# Altium schematic sheet bolid-rs485-usb-sd.SchDoc
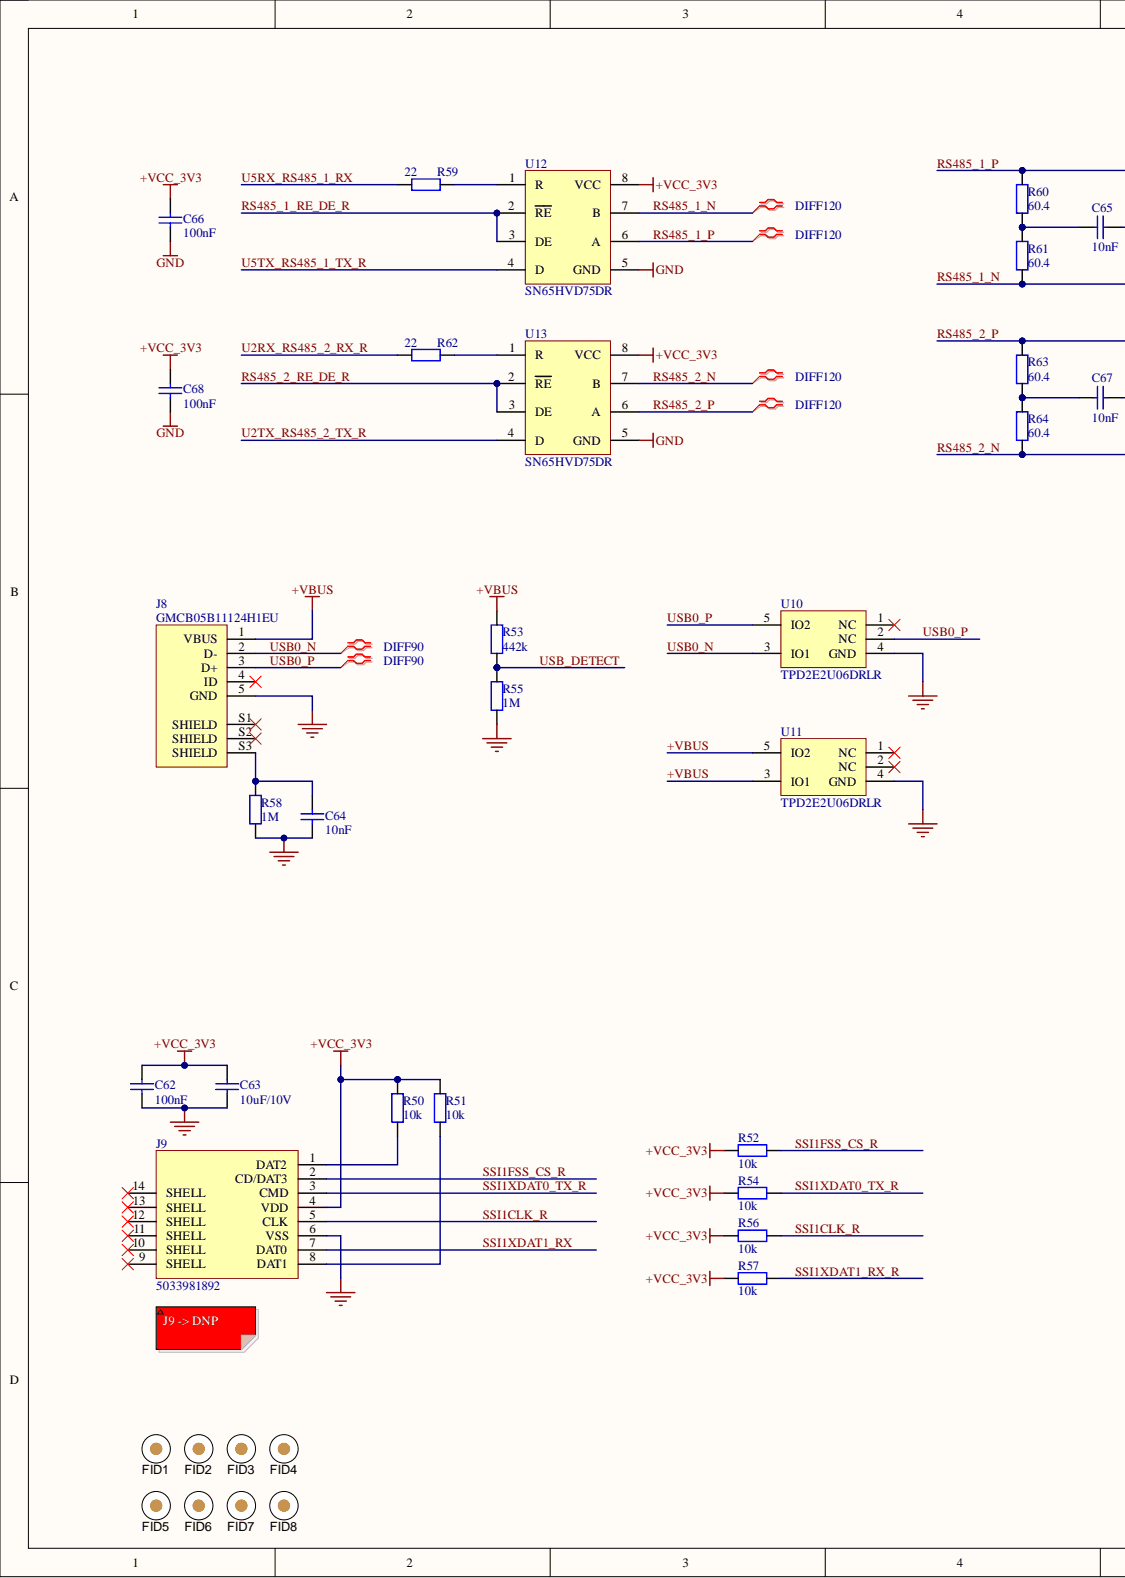
[diagram p0: bolid-rs485-usb-sd.SchDoc, left]
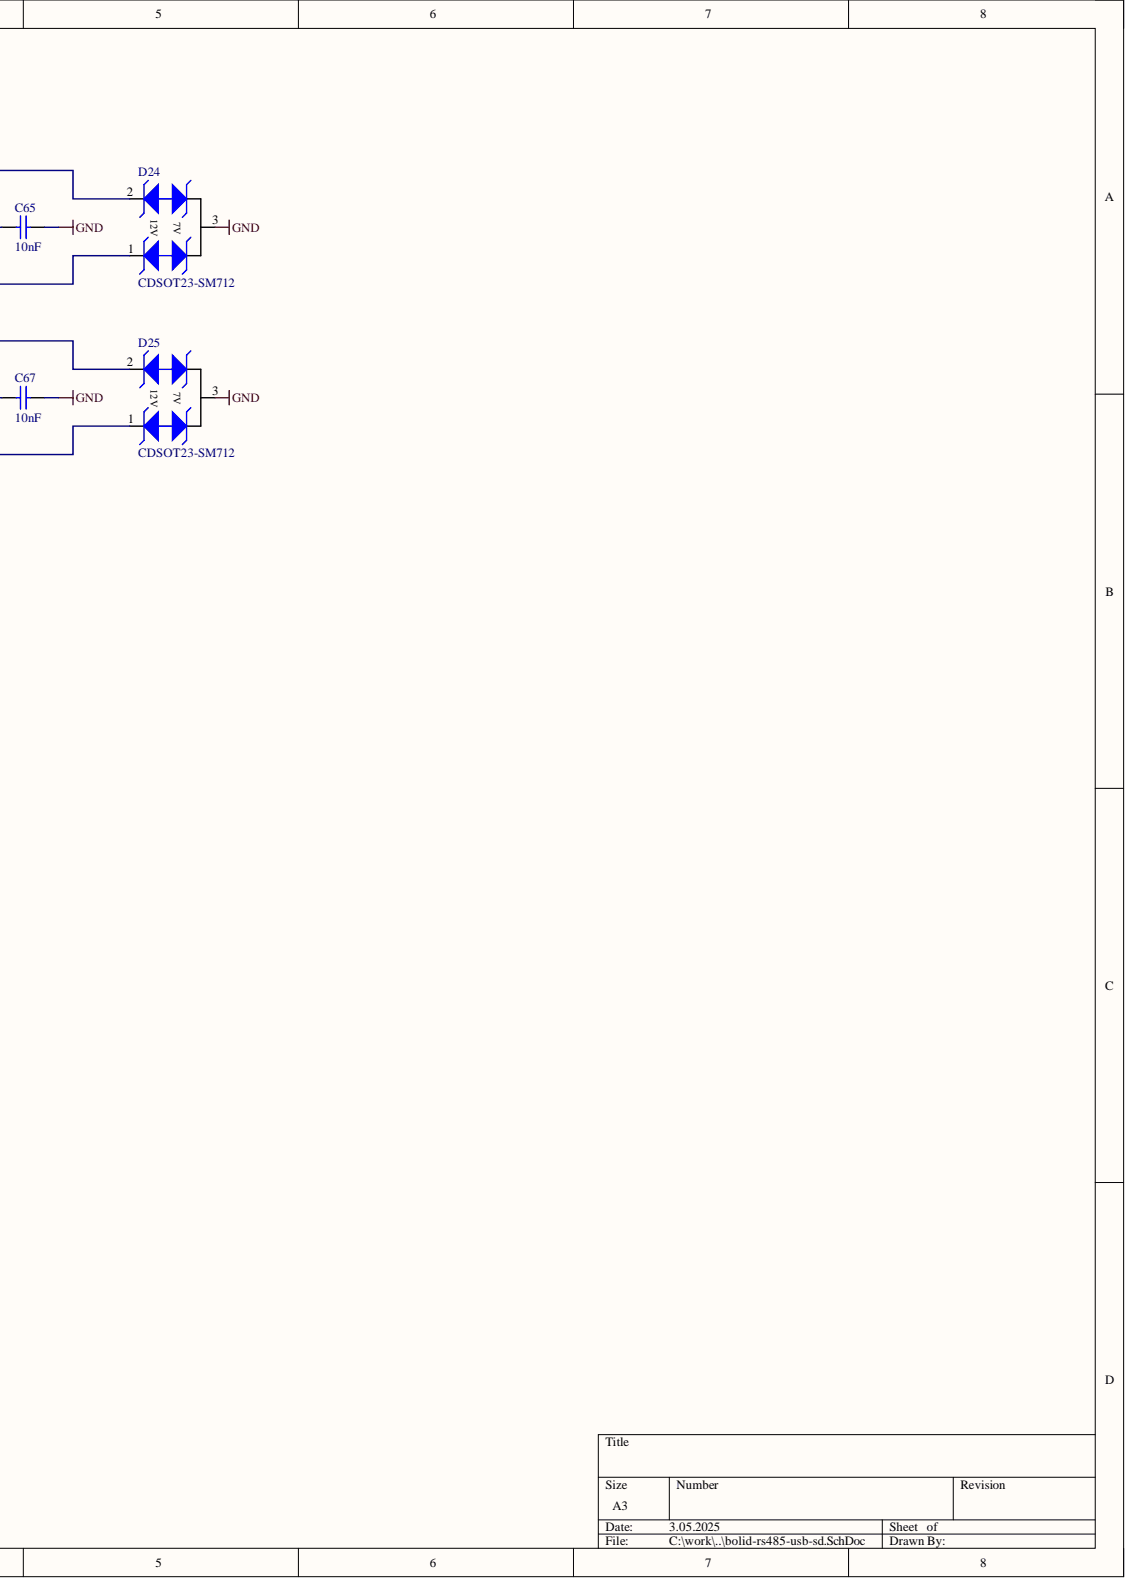
[diagram p1: bolid-rs485-usb-sd.SchDoc, right]

## Parts
C62  value 100nF  [C0402-CAPC100X50X55L22 - Tayio Yuden TDK]  (hidden comment: GRM155R71H104KE14D)
C63  Value Displayed: 10uF/10V  [C0805-CAPC200X125X135L50N - Taiyo YudenN]  (hidden comment: GRM21BR71A106KA73K)
C64  Value Displayed: 10nF  [C0402-CAPC100X50X55L22 - Tayio Yuden TDK]  (hidden comment: GCM155R71H103KA55D)
C65  Value Displayed: 10nF  [C0402-CAPC100X50X55L22 - Tayio Yuden TDK]  (hidden comment: GCM155R71H103KA55D)
C66  value 100nF  [C0402-CAPC100X50X55L22 - Tayio Yuden TDK]  (hidden comment: GRM155R71H104KE14D)
C67  Value Displayed: 10nF  [C0402-CAPC100X50X55L22 - Tayio Yuden TDK]  (hidden comment: GCM155R71H103KA55D)
C68  value 100nF  [C0402-CAPC100X50X55L22 - Tayio Yuden TDK]  (hidden comment: GRM155R71H104KE14D)
D24  CDSOT23-SM712  Reverse Standoff Voltage: 12V  [Bourns SOT-23-3L SOT23-3P95_229X117L49X40N]
D25  CDSOT23-SM712  Reverse Standoff Voltage: 12V  [Bourns SOT-23-3L SOT23-3P95_229X117L49X40N]
FID1  Fiducial  [Fiducial10-20]  (value not drawn)  (no BOM)
FID2  Fiducial  [Fiducial10-20]  (value not drawn)  (no BOM)
FID3  Fiducial  [Fiducial10-20]  (value not drawn)  (no BOM)
FID4  Fiducial  [Fiducial10-20]  (value not drawn)  (no BOM)
FID5  Fiducial  [Fiducial10-20]  (value not drawn)  (no BOM)
FID6  Fiducial  [Fiducial10-20]  (value not drawn)  (no BOM)
FID7  Fiducial  [Fiducial10-20]  (value not drawn)  (no BOM)
FID8  Fiducial  [Fiducial10-20]  (value not drawn)  (no BOM)
J8  GMCB05B11124H1EU  [AMPHENOL-GMCB05B11124H1EU]
J9  5033981892  [MOLEX MICROSD 5033981892]
R50  value 10k  [R0402-RESC100X50X40L22N]  (hidden comment: RC0402FR-0710KL)
R51  value 10k  [R0402-RESC100X50X40L22N]  (hidden comment: RC0402FR-0710KL)
R52  value 10k  [R0402-RESC100X50X40L22N]  (hidden comment: RC0402FR-0710KL)
R53  value 442k  [R0402-RESC100X50X40L22N]  (hidden comment: ERJ-2RKF4423X)
R54  value 10k  [R0402-RESC100X50X40L22N]  (hidden comment: RC0402FR-0710KL)
R55  value 1M  [R0402-RESC100X50X40L22N]  (hidden comment: AC0402FR-071ML)
R56  value 10k  [R0402-RESC100X50X40L22N]  (hidden comment: RC0402FR-0710KL)
R57  value 10k  [R0402-RESC100X50X40L22N]  (hidden comment: RC0402FR-0710KL)
R58  value 1M  [R0402-RESC100X50X40L22N]  (hidden comment: AC0402FR-071ML)
R59  value 22  [R0402-RESC100X50X40L22N]  (hidden comment: RC0402FR-1322RL)
R60  value 60.4  [R0603-RESC160X80X55L30N]  (hidden comment: RC0603FR-0760R4L)
R61  value 60.4  [R0603-RESC160X80X55L30N]  (hidden comment: RC0603FR-0760R4L)
R62  value 22  [R0402-RESC100X50X40L22N]  (hidden comment: RC0402FR-1322RL)
R63  value 60.4  [R0603-RESC160X80X55L30N]  (hidden comment: RC0603FR-0760R4L)
R64  value 60.4  [R0603-RESC160X80X55L30N]  (hidden comment: RC0603FR-0760R4L)
U10  TPD2E2U06DRLR  [TI SOT-5X3 DRL0005A SOTFL50P160X60-5N]
U11  TPD2E2U06DRLR  [TI SOT-5X3 DRL0005A SOTFL50P160X60-5N]
U12  SN65HVD75DR  Case/Package: D  [TI SOIC8 D0008A SOIC8P127_490X599X175L84X41N]
U13  SN65HVD75DR  Case/Package: D  [TI SOIC8 D0008A SOIC8P127_490X599X175L84X41N]

## Nets
+VCC_3V3: C62.2 C63.1 C66.1 C68.1 J9.4(VDD) R50.1 R51.1 R52.1 R54.1 R56.1 R57.1 U12.8(VCC) U13.8(VCC)
GND: C62.1 C63.2 C64.1 C65.2 C66.2 C67.2 C68.2 D24.3 D25.3 J8.5(GND) J9.6(VSS) R55.1 R58.1 U10.4(GND) U11.4(GND) U12.5(GND) U13.5(GND)
+VBUS: J8.1(VBUS) R53.2 U11.3(IO1) U11.5(IO2)
RS485_1_N: D24.1 R61.1 U12.7(B)
RS485_1_P: D24.2 R60.2 U12.6(A)
RS485_1_RE_DE_R: U12.2(RE) U12.3(DE)
RS485_2_N: D25.1 R64.1 U13.7(B)
RS485_2_P: D25.2 R63.2 U13.6(A)
RS485_2_RE_DE_R: U13.2(RE) U13.3(DE)
SSI1CLK_R: J9.5(CLK) R56.2
SSI1FSS_CS_R: J9.2(CD/DAT3) R52.2
SSI1XDAT0_TX_R: J9.3(CMD) R54.2
SSI1XDAT1_RX: J9.7(DAT0)
SSI1XDAT1_RX_R: R57.2
U2RX_RS485_2_RX_R: R62.1
U2TX_RS485_2_TX_R: U13.4(D)
U5RX_RS485_1_RX: R59.1
U5TX_RS485_1_TX_R: U12.4(D)
USB0_N: J8.2(D-) U10.3(IO1)
USB0_P: J8.3(D+) U10.2(NC) U10.5(IO2)
USB_DETECT: R53.1 R55.2
NetC64_2: C64.2 J8.S3(SHIELD) R58.2
NetC65_1: C65.1 R60.1 R61.2
NetC67_1: C67.1 R63.1 R64.2
NetJ9_1: J9.1(DAT2) R50.2
NetJ9_8: J9.8(DAT1) R51.2
NetR59_2: R59.2 U12.1(R)
NetR62_2: R62.2 U13.1(R)

Not connected: FID1 X; FID2 X; FID3 X; FID4 X; FID5 X; FID6 X; FID7 X; FID8 X; J8 4(ID) S1(SHIELD) S2(SHIELD); J9 9(SHELL) 10(SHELL) 11(SHELL) 12(SHELL) 13(SHELL) 14(SHELL); U10 1(NC); U11 1(NC) 2(NC)

## Library data of the parts
C62 C66 C68 = GRM155R71H104KE14D: MLCC 0402 (1005) 100nF 10% 50V X7R; Brand: Murata Electronics; Capacitance: 0.1 uF; Capacitance - pF: 100000 pF; Class: Class 2; Type: Chip Multilayer Ceramic Capacitor for General Purpose; Voltage Rating DC: 50 VDC; Capacitance - nF: 100 nF; Case Code - in: 0402; Case Code - mm: 1005; Category: Multilayer Ceramic Capacitors MLCC - SMD/SMT; Component Kind: Standard; ComponentLink1Description: https://www.mouser.com/datasheet/2/281/murata_03052018_GRM_Series_1-1310166.pdf; ComponentLink1URL: https://www.mouser.com/datasheet/2/281/murata_03052018_GRM_Series_1-1310166.pdf; Dielectric: X7R; Height: 0.5 mm; Length: 1 mm; Library Name: capacitors2.IntLib; Manufacturer: Murata Electronics; Manufacturer Part Number: GRM155R71H104KE14D; Maximum Operating Temperature: + 125 C; Minimum Operating Temperature: - 55 C; Minimum Order Quantity: 1; Package / Case: 0402 (1005 metric); Packaging: Cut Tape; Pricing 1: 1=0.090, 10=0.038, 100=0.016, 500=0.012, 1000=0.009, 2500=0.008, 5000=0.007, 10000=0.005 (EUR); Product: General Type MLCCs; Product Type: Ceramic Capacitors; Reeling: True; RoHS: RoHS Compliant; RoHS - Mouser: Y; Series: GRM; Signal Integrity: Cap Semi; Simulation: CAP; Standard Pack Qty: 10000; Stock 1: 3511637; Subcategory: Capacitors; Supplier 1: Mouser; Supplier Part Number 1: 81-GRM155R71H104KE4D; Termination: Standard; Termination Style: SMD/SMT; Tolerance: 10 %; Value Displayed: 100nF; Width: 0.5 mm
C63 = GRM21BR71A106KA73K: MLCC 0805 (2012) 10uF 10% 10V X7R; Brand: Murata Electronics; Class: Class 2; Type: Chip Multilayer Ceramic Capacitor for General Purpose; Value: 10u; Voltage Rating DC: 10 VDC; Capacitance: 10 uF; Case Code - in: 0805; Case Code - mm: 2012; Category: Multilayer Ceramic Capacitors MLCC - SMD/SMT; Component Kind: Standard; ComponentLink1Description: https://www.mouser.com/datasheet/2/281/murata_03052018_GRM_Series_1-1310166.pdf; ComponentLink1URL: https://www.mouser.com/datasheet/2/281/murata_03052018_GRM_Series_1-1310166.pdf; Dielectric: X7R; Height: 1.25 mm; Length: 2 mm; Library Name: capacitors2.IntLib; Manufacturer: Murata Electronics; Manufacturer Part Number: GRM21BR71A106KA73K; Maximum Operating Temperature: + 125 C; Minimum Operating Temperature: - 55 C; Minimum Order Quantity: 1; Package / Case: 0805 (2012 metric); Packaging: Cut Tape; Pricing 1: 1=0.207, 10=0.144, 100=0.072, 500=0.050, 1000=0.043, 2500=0.040, 5000=0.036, 10000=0.030, 20000=0.028 (EUR); Product: General Type MLCCs; Product Type: Ceramic Capacitors; Reeling: True; RoHS: RoHS Compliant; RoHS - Mouser: Y; Series: GRM; Signal Integrity: Cap Semi; Simulation: CAP; Standard Pack Qty: 10000; Stock 1: 108729; Subcategory: Capacitors; Supplier 1: Mouser; Supplier Part Number 1: 81-GRM21BR71A106KA3K; Termination: Standard; Termination Style: SMD/SMT; Tolerance: 10 %; Width: 1.25 mm
C64 C65 C67 = GCM155R71H103KA55D: MLCC 0402 (1005) 10nF 10% 50V X7R; Brand: Murata Electronics; Capacitance: 0.01 uF; Capacitance - pF: 10000 pF; Class: Class 2; Qualification: AEC-Q200; Type: Chip Multilayer Ceramic Capacitors for Automotive; Value: 10n; Voltage Rating DC: 50 VDC; Capacitance - nF: 10 nF; Case Code - in: 0402; Case Code - mm: 1005; Category: Multilayer Ceramic Capacitors MLCC - SMD/SMT; Component Kind: Standard; ComponentLink1Description: https://www.mouser.com/datasheet/2/281/murata_03122018_GCM_Series-1310150.pdf; ComponentLink1URL: https://www.mouser.com/datasheet/2/281/murata_03122018_GCM_Series-1310150.pdf; Dielectric: X7R; Height: 0.5 mm; Length: 1 mm; Library Name: capacitors2.IntLib; Manufacturer: Murata Electronics; Manufacturer Part Number: GCM155R71H103KA55D; Maximum Operating Temperature: + 125 C; Minimum Operating Temperature: - 55 C; Minimum Order Quantity: 1; Package / Case: 0402 (1005 metric); Packaging: Cut Tape; Pricing 1: 1=0.090, 10=0.033, 100=0.015, 500=0.011, 1000=0.009, 2500=0.008, 5000=0.006, 10000=0.005, 20000=0.004 (EUR); Product: Automotive MLCCs; Product Type: Ceramic Capacitors; Reeling: True; RoHS: RoHS Compliant; RoHS - Mouser: Y; Series: GCM; Signal Integrity: Cap Semi; Simulation: CAP; Standard Pack Qty: 10000; Stock 1: 7174893; Subcategory: Capacitors; Supplier 1: Mouser; Supplier Part Number 1: 81-GCM155R71H103KA5D; Termination: Standard; Termination Style: SMD/SMT; Tolerance: 10 %; Width: 0.5 mm
D24 D25 = CDSOT23-SM712: TVS DIODE 7VWM/12VWM SOT23; Manufacturer: Bourns; Breakdown Voltage: 13.3V; Capacitance: 75pF; Clamping Voltage: 19V; Diode Type: ZENER; Direction: Bidirectional; Element Configuration: Dual; ESD Protection: Yes; Leakage Current: 20uA; Max Power Dissipation: 600W; Max Surge Current: 30A; Number of Bidirectional Channels: 2; Peak Pulse Current: 17A; Peak Pulse Power: 400W; Polarity: Bidirectional,Unidirectional; Power Line Protection: No; Test Current: 1mA; Case/Package: SOT-23; Category: TVS Diodes; Component Kind: Standard; ComponentLink1Description: https://datasheet.ciiva.com/1281/1670933-1281331.pdf?src-supplier=Farnell; ComponentLink1URL: https://datasheet.ciiva.com/1281/1670933-1281331.pdf?src-supplier=Farnell; ComponentLink2Description: https://datasheet.ciiva.com/4510/cdsot23-sm712-25932-4510261.pdf?src-supplier=Mouser; ComponentLink2URL: https://datasheet.ciiva.com/4510/cdsot23-sm712-25932-4510261.pdf?src-supplier=Mouser; ComponentLink3Description: https://datasheet.ciiva.com/11193/cdsot23-sm712-11193846.pdf?src-supplier=Avnet; ComponentLink3URL: https://datasheet.ciiva.com/11193/cdsot23-sm712-11193846.pdf?src-supplier=Avnet; ComponentLink4Description: https://datasheet.ciiva.com/22696/1670933-22696779.pdf?src-supplier=Element14; ComponentLink4URL: https://datasheet.ciiva.com/22696/1670933-22696779.pdf?src-supplier=Element14; ComponentLink5Description: https://datasheet.ciiva.com/26828/getdatasheetpartid-418501-26828522.pdf?src-supplier=Verical; ComponentLink5URL: https://datasheet.ciiva.com/26828/getdatasheetpartid-418501-26828522.pdf?src-supplier=Verical; ComponentLink6Description: https://datasheet.ciiva.com/337/cdsot23-sm712-337451.pdf?src-supplier=Digi-Key; ComponentLink6URL: https://datasheet.ciiva.com/337/cdsot23-sm712-337451.pdf?src-supplier=Digi-Key; ComponentLink7Description: https://datasheet.ciiva.com/337/cdsot23-sm712-337452.pdf?src-supplier=Digi-Key; ComponentLink7URL: https://datasheet.ciiva.com/337/cdsot23-sm712-337452.pdf?src-supplier=Digi-Key; Contact Plating: Tin; Depth: 1.4mm; Height: 1.11mm; Length: 3.04mm; Library Name: semiconductors2.IntLib; Manufacturer Part Number: CDSOT23-SM712; Max Breakdown Voltage: 13.3V; Max Operating Temperature: 150°C; Max Reverse Leakage Current: 20uA; Min Breakdown Voltage: 13.3V; Min Operating Temperature: -55°C; Mount: Surface Mount; Number of Channels: 2; Number of Elements: 2; Number of Pins: 3; Package Quantity: 1; Packaging: Tape and Reel; Power Dissipation: 400W; Pricing 1: 1=1,63000, 10=1,44000, 50=1,44000, 100=1,14000, 250=1,11000, 500=0,94100, 1000=0,74300, 3000=0,69300, 6000=0,67500, 9000=0,62900, 10000=0,62900 (USD); Radiation Hardening: No; REACH SVHC: No SVHC; Resistor Type: Zener; Reverse Breakdown Voltage: 13.3V; RoHS: Yes; RoHS Compliant: Yes; Series: -; Stock 1: 1112; Supplier 1: Mouser; Supplier Part Number 1: 652-CDSOT23-SM712; Termination: SMD/SMT; Width: 0.055inch; Working Voltage: 12V
FID1-FID8 = Fiducial: Fiducial mark on PCB; Library Name: hardware2.IntLib
J8 = GMCB05B11124H1EU: Micro USB 2.0 Type B Receptacle Vertical SMT Shell Dip Length 1.7mm, Tape and Reel Packagin; Manufacturer: Amphenol; Category: Connectors; Component Kind: Standard; Library Name: connectors2.IntLib; Manufacturer Part Number: GMCB05B11124H1EU; Pricing 1: 1=1,01, 10=0,773, 50=0,641, 100=0,592, 1000=0,483, 10000=0,47 (USD); RoHS: No; Stock 1: 17853; Supplier 1: Mouser; Supplier Part Number 1: 523-GMCB05B11124H1EU
J9 = 5033981892: 1.10mm Pitch microSD Memory Card Connector, Normal Mount Surface Mount, Push-Push Type, 1.28mm Height; Manufacturer: Molex; Current Rating: 500mA; Gender: Male; Memory Type: 4498,11064MemoryType; Number of Circuits: 8; Pitch: 1.1mm; Plating: 154ConductorMaterial; Voltage Rating: 10V; Category: Memory Connectors; Component Kind: Standard; ComponentLink1Description: https://datasheet.ciiva.com/23941/1740947-23941665.pdf?src-supplier=Newark; ComponentLink1URL: https://datasheet.ciiva.com/23941/1740947-23941665.pdf?src-supplier=Newark; ComponentLink2Description: https://datasheet.ciiva.com/22744/1740946-22744573.pdf?src-supplier=Element14; ComponentLink2URL: https://datasheet.ciiva.com/22744/1740946-22744573.pdf?src-supplier=Element14; Contact Material: Bronze,Stainless Steel; Contact Plating: Gold; Depth: 14.05mm; Halogen Free: Low Halogen; Housing Material: Thermoplastic; Length: 13.6mm; Library Name: connectors2.IntLib; Manufacturer Part Number: 5033981892; Max Operating Temperature: 85°C; Min Operating Temperature: -25°C; Mount: Surface Mount; Number of Contacts: 8; Number of Rows: 1; Orientation: Right Angle; Package Quantity: 2400; Packaging: Tape and Reel; Pricing 1: 1=2,97, 10=1,94, 50=1,94, 100=1,68, 1000=1,5, 10000=1,35 (USD); Reach SVHC Compliant: Unknown; RoHS: Yes; RoHS Compliant: Yes; Series: 503398; Stock 1: 16315; Supplier 1: Mouser; Supplier Part Number 1: 538-503398-1892; Termination: Solder; Voltage Rating (AC): 10V; Width: 14.05mm
R50-R52 R54 R56 R57 = RC0402FR-0710KL: RES 0402 (1005) 10.0k 1% 0.0625W 50V Thick Film; Brand: Yageo; Power Rating: 62.5 mW, 125 mW; Resistance: 10 kOhms; Temperature Coefficient: 100 PPM / C; Application: High Reliability; Case Code - in: 0402; Case Code - mm: 1005; Category: Thick Film Resistors - SMD; Component Kind: Standard; ComponentLink1Description: https://www.mouser.com/datasheet/2/447/PYu-RC_Group_51_RoHS_L_10-1664068.pdf; ComponentLink1URL: https://www.mouser.com/datasheet/2/447/PYu-RC_Group_51_RoHS_L_10-1664068.pdf; Features: -; Height: 0.35 mm; Length: 1 mm; Library Name: resistors2.IntLib; Manufacturer: Yageo; Manufacturer Part Number: RC0402FR-0710KL; Maximum Operating Temperature: + 155 C; Minimum Operating Temperature: - 55 C; Minimum Order Quantity: 1; Mounting Style: PCB Mount; Package / Case: -; Packaging: Cut Tape; Pricing 1: 1=0.090, 10=0.011, 100=0.004, 1000=0.003, 10000=0.003 (EUR); Product: Thick Film Resistors SMD; Product Type: Thick Film Resistors; Reeling: True; RoHS: RoHS Compliant; RoHS - Mouser: Y; Series: RC; Signal Integrity: Res; Simulation: RESISTOR; Standard Pack Qty: 10000; Stock 1: 20942447; Subcategory: Resistors; Supplier 1: Mouser; Supplier Part Number 1: 603-RC0402FR-0710KL; Technology: Thick Film; Termination Style: SMD/SMT; Tolerance: 1 %; Type: General Purpose; Voltage Rating: 50 V; Width: 0.5 mm
R53 = ERJ-2RKF4423X: RES 0402 (1005) 442.0k 1% 0.100W 50V Thick Film; Brand: Panasonic; Power Rating: 100 mW (1/10 W); Qualification: AEC-Q200; Resistance: 442 kOhms; Temperature Coefficient: 100 PPM / C; Application: Automotive Grade; Case Code - in: 0402; Case Code - mm: 1005; Category: Thick Film Resistors - SMD; Component Kind: Standard; ComponentLink1Description: https://www.mouser.com/datasheet/2/315/AOA0000C304-1149620.pdf; ComponentLink1URL: https://www.mouser.com/datasheet/2/315/AOA0000C304-1149620.pdf; Features: Precision Resistors; Height: 0.35 mm; Length: 1 mm; Library Name: resistors2.IntLib; Manufacturer: Panasonic; Manufacturer Part Number: ERJ-2RKF4423X; Maximum Operating Temperature: + 155 C; Minimum Operating Temperature: - 55 C; Minimum Order Quantity: 1; Mounting Style: PCB Mount; Packaging: Cut Tape; Pricing 1: 1=0.085, 10=0.025, 100=0.010, 1000=0.005, 2500=0.004, 5000=0.003, 10000=0.003 (EUR); Product: Thick Film Resistors SMD; Product Type: Thick Film Resistors; Reeling: True; RoHS: RoHS Compliant By Exemption; RoHS - Mouser: E; Series: ERJ-2Rx; Signal Integrity: Res; Simulation: RESISTOR; Standard Pack Qty: 10000; Stock 1: 56067; Subcategory: Resistors; Supplier 1: Mouser; Supplier Part Number 1: 667-ERJ-2RKF4423X; Technology: Thick Film; Termination Style: SMD/SMT; Tolerance: 1 %; Voltage Rating: 50 V; Width: 0.5 mm
R55 R58 = AC0402FR-071ML: RES 0402 (1005) 1.00M 1% 0.0625W 50V Thick Film; Brand: YAGEO; Power Rating: 62.5 mW (1/16 W); Qualification: AEC-Q200; Resistance: 1 MOhms; Temperature Coefficient: 100 PPM / C; Application: Automotive Grade; Case Code - in: 0402; Case Code - mm: 1005; Category: Thick Film Resistors - SMD; Component Kind: Standard; ComponentLink1Description: https://www.mouser.com/datasheet/2/447/Yageo_PYu_AC_51_RoHS_L_7_1714230-1874691.pdf; ComponentLink1URL: https://www.mouser.com/datasheet/2/447/Yageo_PYu_AC_51_RoHS_L_7_1714230-1874691.pdf; Height: 0.32 mm; Length: 1 mm; Library Name: resistors2.IntLib; Manufacturer: YAGEO; Manufacturer Part Number: AC0402FR-071ML; Maximum Operating Temperature: + 155 C; Minimum Operating Temperature: - 55 C; Minimum Order Quantity: 1; Mounting Style: PCB Mount; Packaging: Cut Tape; Pricing 1: 1=0.085, 10=0.019, 100=0.008, 1000=0.004, 2500=0.003, 10000=0.003 (EUR); Product: Thick Film Resistors General Purpose; Product Type: Thick Film Resistors; Reeling: True; RoHS: RoHS Compliant By Exemption; RoHS - Mouser: E; Series: AC; Signal Integrity: Res; Simulation: RESISTOR; Standard Pack Qty: 10000; Stock 1: 142366; Subcategory: Resistors; Supplier 1: Mouser; Supplier Part Number 1: 603-AC0402FR-071ML; Technology: Thick Film; Termination Style: SMD/SMT; Tolerance: 1 %; Type: General Purpose Resistor; Voltage Rating: 50 V; Width: 0.5 mm
R59 R62 = RC0402FR-1322RL: RES 0402 (1005) 22.0 ohm 1% 0.0625W 50V Thick Film; Manufacturer: Yageo; Power Rating: 62.5mW; Resistance: 22R; Temperature Coefficient: 100ppm/°C; Case Code (Imperial): 0402; Case Code (Metric): 1005; Case/Package: 0402; Category: Chip SMD Resistors; Component Kind: Standard; ComponentLink1Description: https://datasheet.ciiva.com/17423/pyu-rc0402-51-rohs-l-6-r-17423721.pdf?src-supplier=Future+Electronics; ComponentLink1URL: https://datasheet.ciiva.com/17423/pyu-rc0402-51-rohs-l-6-r-17423721.pdf?src-supplier=Future+Electronics; ComponentLink2Description: https://datasheet.ciiva.com/8890/pyu-rc0402-51-rohs-l-6-8890187.pdf?src-supplier=Avnet; ComponentLink2URL: https://datasheet.ciiva.com/8890/pyu-rc0402-51-rohs-l-6-8890187.pdf?src-supplier=Avnet; Contact Plating: Tin,Matte; Height: 0.35mm; Lead Free: Lead Free; Length: 1mm; Library Name: resistors2.IntLib; Manufacturer Part Number: RC0402FR-1322RL; Max Operating Temperature: 155°C; Min Operating Temperature: -55°C; Packaging: Tape and Reel; Pricing 1: 1=0,10000, 10=0,01200, 50=0,01200, 100=0,00500, 1000=0,00300, 10000=0,00300 (USD); Radiation Hardening: No; Resistor Type: Thick Film; RoHS: Yes; RoHS Compliant: Yes; Series: RC; Signal Integrity: Res; Simulation: RESISTOR; Size Code: 0402; Stock 1: 130563; Supplier 1: Mouser; Supplier Part Number 1: 603-RC0402FR-1322RL; Termination: SMD/SMT; Tolerance: 1%; Voltage Rating: 50V; Weight: 2.3E-05oz; Width: 0.5mm
R60 R61 R63 R64 = RC0603FR-0760R4L: RES 0603 (1608) 60.4 ohm 1% 0.100W 75V Thick Film; Brand: Yageo; Power Rating: 100 mW (1/10 W); Resistance: 60.4 Ohms; Temperature Coefficient: 100 PPM / C; Application: High Reliability; Case Code - in: 0603; Case Code - mm: 1608; Category: Thick Film Resistors - SMD; Component Kind: Standard; ComponentLink1Description: https://www.mouser.com/datasheet/2/447/PYu-RC_Group_51_RoHS_L_10-1664068.pdf; ComponentLink1URL: https://www.mouser.com/datasheet/2/447/PYu-RC_Group_51_RoHS_L_10-1664068.pdf; Features: -; Height: 0.45 mm; Length: 1.6 mm; Library Name: resistors2.IntLib; Manufacturer: Yageo; Manufacturer Part Number: RC0603FR-0760R4L; Maximum Operating Temperature: + 155 C; Minimum Operating Temperature: - 55 C; Minimum Order Quantity: 1; Mounting Style: PCB Mount; Package / Case: -; Packaging: Cut Tape; Pricing 1: 1=0.090, 10=0.016, 100=0.005, 5000=0.003 (EUR); Product: Thick Film Resistors SMD; Product Type: Thick Film Resistors; Reeling: True; RoHS: RoHS Compliant By Exemption; RoHS - Mouser: E; Series: RC; Signal Integrity: Res; Simulation: RESISTOR; Standard Pack Qty: 5000; Stock 1: 301644; Subcategory: Resistors; Supplier 1: Mouser; Supplier Part Number 1: 603-RC0603FR-0760R4L; Technology: Thick Film; Termination Style: SMD/SMT; Tolerance: 1 %; Type: General Purpose; Voltage Rating: 75 V; Width: 0.8 mm
U10 U11 = TPD2E2U06DRLR: TVS DIODE 5.5VWM 12.4VC 5SOT; Manufacturer: Texas Instruments; Breakdown Voltage: 8.5V; Capacitance: 1.9pF; Clamping Voltage: 12.4V; Direction: Unidirectional; Interface: LVDS,USB,Ethernet; Min Breakdown Voltage: 6.5V; Number of Channels: 2; Operating Supply Voltage: 5.5V; Peak Pulse Current: 5.5A; Peak Pulse Power: 85W; Power Line Protection: Yes; Case/Package: SOT-5; Category: Integrated Circuits (ICs); Component Kind: Standard; ComponentLink1Description: https://datasheet.ciiva.com/48533/tpd2e2u06-48533343.pdf?src-supplier=Avnet; ComponentLink1URL: https://datasheet.ciiva.com/48533/tpd2e2u06-48533343.pdf?src-supplier=Avnet; Lead Free: Lead Free; Library Name: semiconductors2.IntLib; Manufacturer Part Number: TPD2E2U06DRLR; Max Operating Temperature: 125°C; Min Operating Temperature: -40°C; Mount: Surface Mount; Number of Pins: 5; Number of Unidirectional Channels: 2; Package Quantity: 4000; Packaging: Tape and Reel; Polarity: Unidirectional; Pricing 1: 1=0,49, 10=0,356, 50=0,356, 100=0,254, 1000=0,173, 10000=0,159 (USD); Reach SVHC Compliant: No; Reverse Standoff Voltage: 5.5V; RoHS: Yes; RoHS Compliant: Yes; Series: -; Stock 1: 73856; Supplier 1: Mouser; Supplier Part Number 1: 595-TPD2E2U06DRLR; Termination: SMD/SMT; Working Voltage: 5.5V
U12 U13 = SN65HVD75DR: IC TXRX RS485 3.3V LP 8SOIC; Manufacturer: Texas Instruments; Data Rate: 20Mbps; Interface: RS-422,RS-485; Logic Function: Transceiver; Max Supply Voltage: 3.6V; Min Supply Voltage: 3V; Nominal Supply Current: 950uA; Number of Drivers: 1; Receiver Hysteresis: 80mV; Simplex/Duplex: Half Duplex; Category: RS-232 / RS-422 / RS-485 Interface ICs; Component Kind: Standard; ComponentLink2Description: https://datasheet.ciiva.com/26946/getdatasheetpartid-520468-26946072.pdf?src-supplier=Verical; ComponentLink2URL: https://datasheet.ciiva.com/26946/getdatasheetpartid-520468-26946072.pdf?src-supplier=Verical; ComponentLink3Description: https://datasheet.ciiva.com/14195/sn65hvd75-14195222.pdf?src-supplier=Avnet; ComponentLink3URL: https://datasheet.ciiva.com/14195/sn65hvd75-14195222.pdf?src-supplier=Avnet; ComponentLink4Description: https://datasheet.ciiva.com/6635/sn65hvd75-6635111.pdf?src-supplier=Digi-Key; ComponentLink4URL: https://datasheet.ciiva.com/6635/sn65hvd75-6635111.pdf?src-supplier=Digi-Key; Contact Plating: Gold; Lead Free: Lead Free; Library Name: ics2.IntLib; Manufacturer Part Number: SN65HVD75DR; Max Operating Temperature: 125°C; Min Operating Temperature: -40°C; Number of Pins: 8; Number of Receivers: 1; Number of Transceivers: 1; Number of Transmitters: 1; Operating Supply Voltage: 3.3V; Package Quantity: 2500; Packaging: Tape and Reel; Pricing 1: 1=3,10000, 10=2,79000, 25=2,63000, 50=2,63000, 100=2,28000, 250=2,17000, 500=1,94000, 1000=1,70000, 2500=1,69000, 10000=1,69000 (USD); Radiation Hardening: No; REACH SVHC: No SVHC; RoHS: Yes; RoHS Compliant: Yes; Series: -; Supplier 1: Mouser; Supplier Part Number 1: 595-SN65HVD75DR; Supply Current: 950uA
